# Altium schematic sheet [09] - SWITCHES AND INPUTS.SchDoc
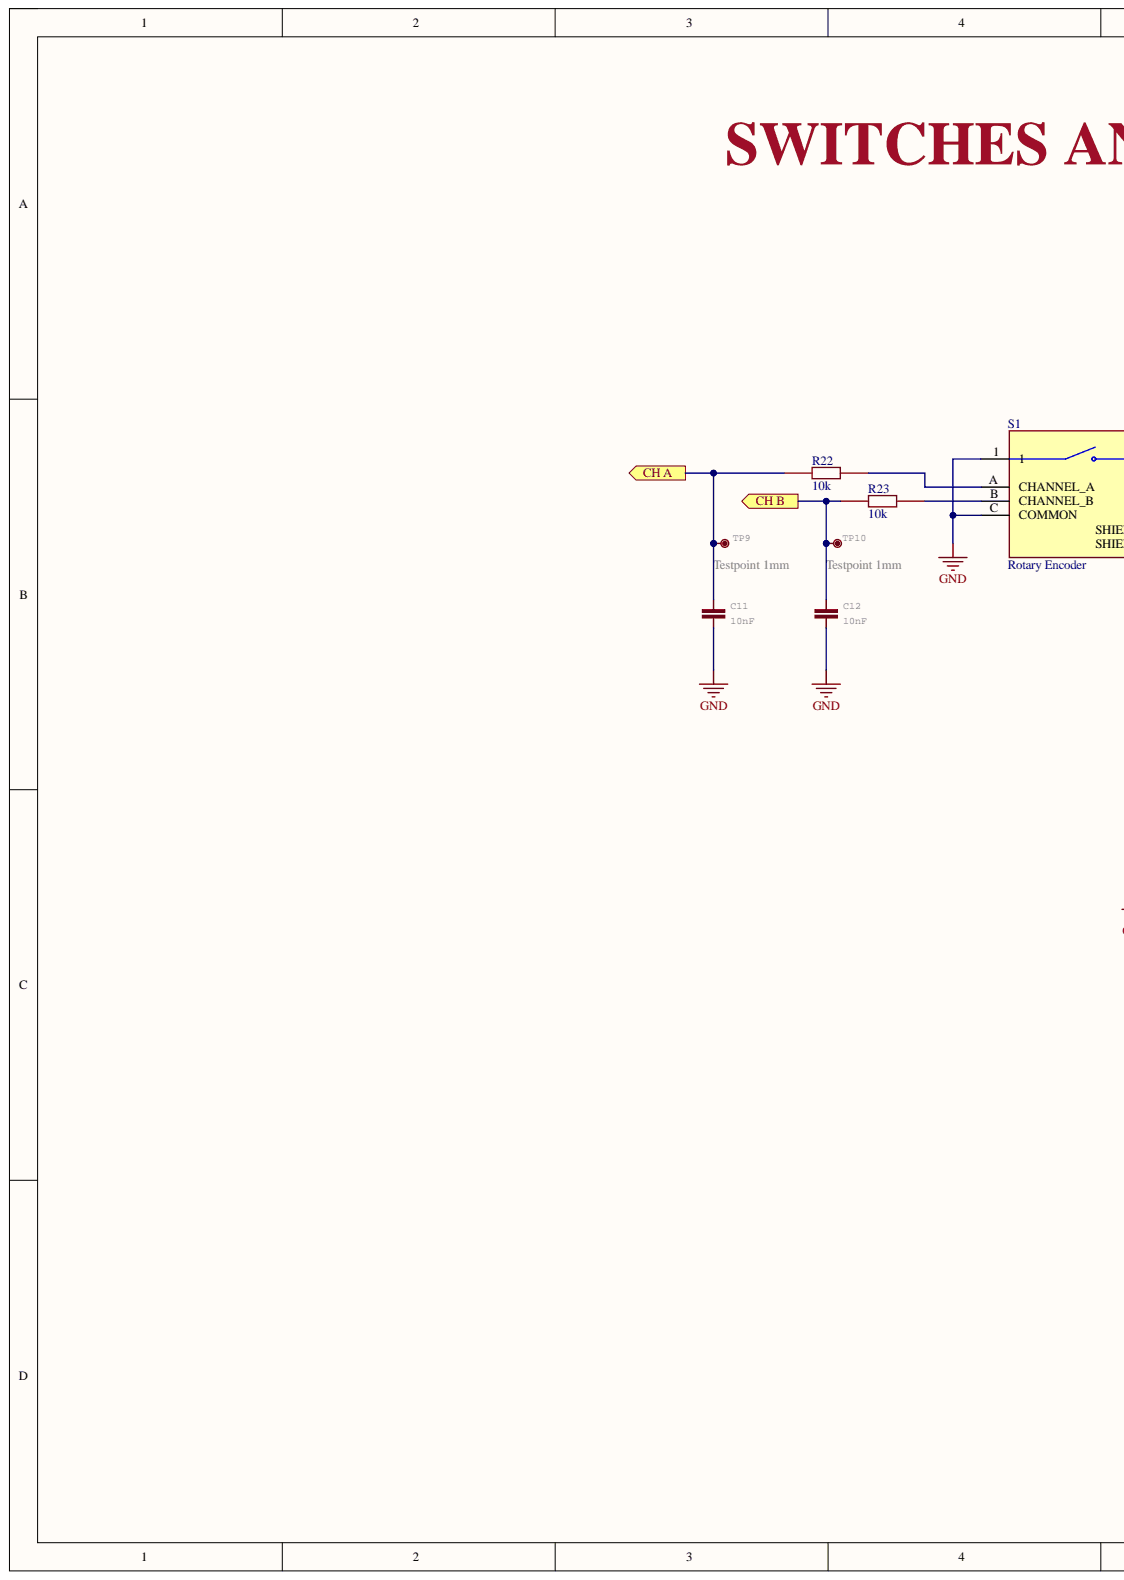
[diagram p0: [09] - SWITCHES AND INPUTS.SchDoc, left]
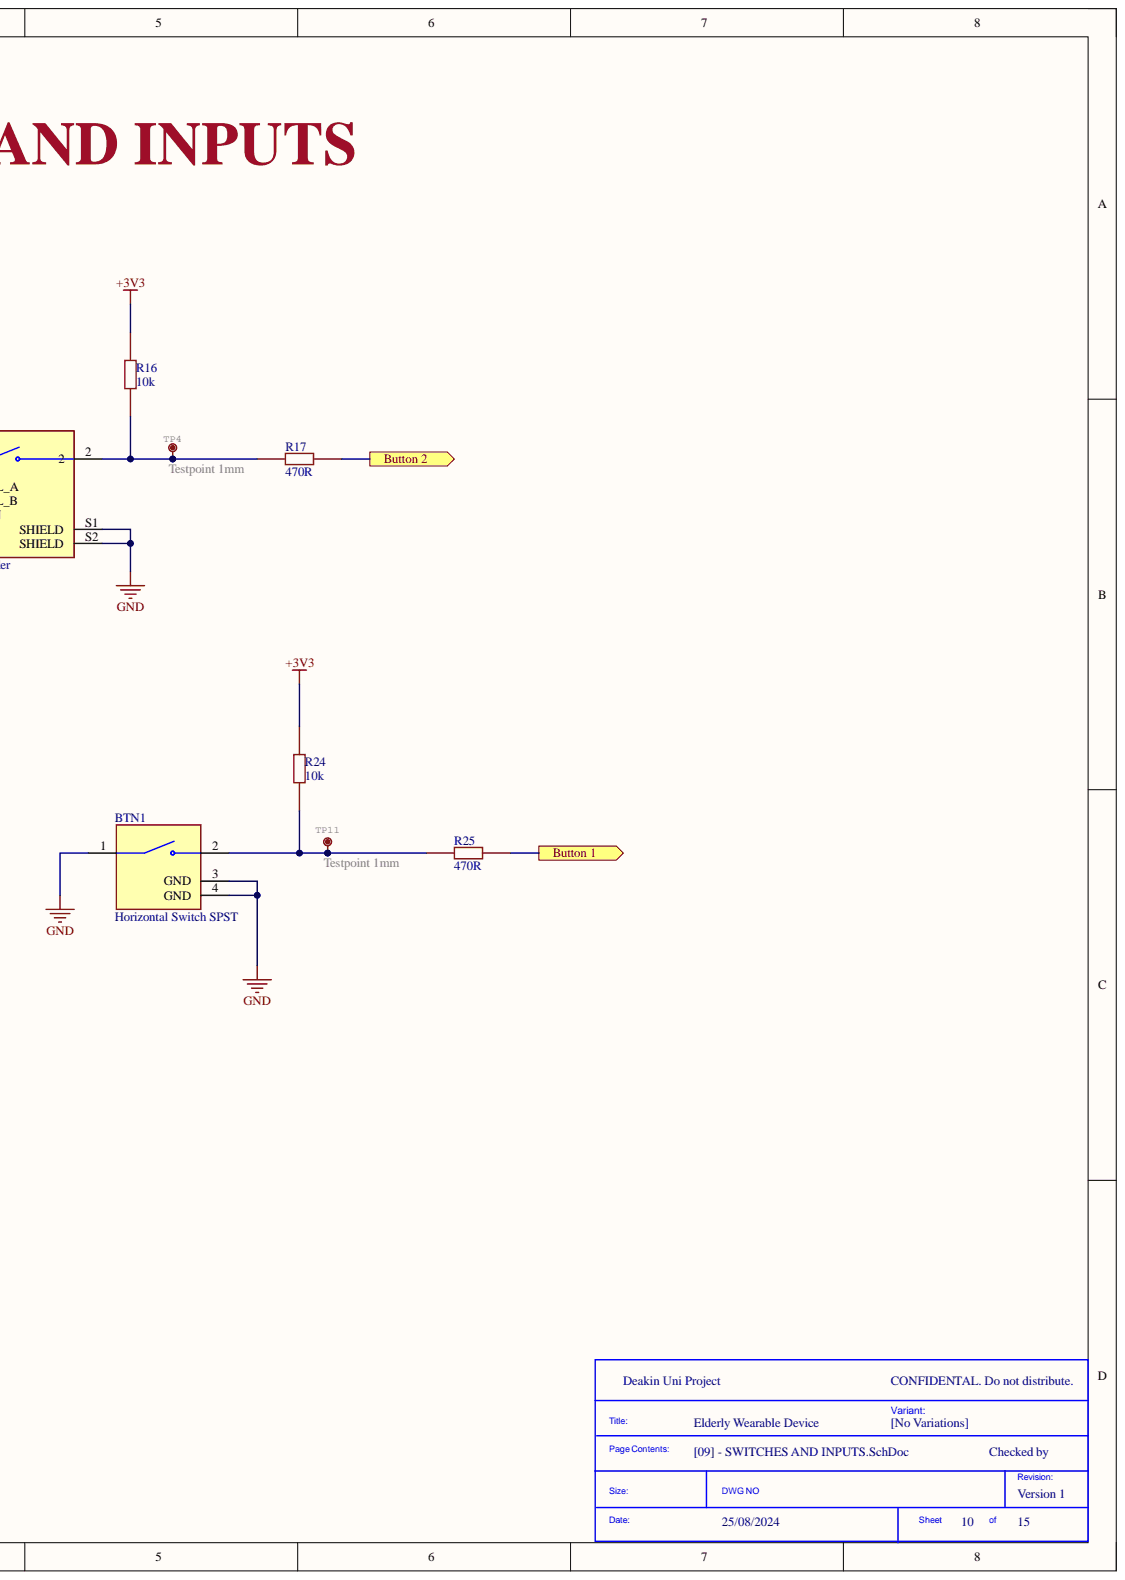
[diagram p1: [09] - SWITCHES AND INPUTS.SchDoc, right]

## Parts
BTN1  Horizontal Switch SPST  [Horizontal_Switch]
C11  10nF  [C_0402]
C12  10nF  [C_0402]
R16  10k  [R_0603]
R17  470R  [R_0603]
R22  10k  [R_0603]
R23  10k  [R_0603]
R24  10k  [R_0603]
R25  470R  [R_0603]
S1  Rotary Encoder  [Rotary_Encoder]
TP4  Testpoint 1mm  [Testpoint_1mm]
TP9  Testpoint 1mm  [Testpoint_1mm]
TP10  Testpoint 1mm  [Testpoint_1mm]
TP11  Testpoint 1mm  [Testpoint_1mm]

## Ports
Button 1, Button 2, CH A, CH B

## Nets
+3V3: R16.2 R24.2
GND: BTN1.1 BTN1.3(GND) BTN1.4(GND) C11.2 C12.2 S1.1 S1.C(COMMON) S1.S1(SHIELD) S1.S2(SHIELD)
Button 1: R25.2 ; port Button 1
Button 2: R17.2 ; port Button 2
CH A: C11.1 R22.2 TP9.X ; port CH A
CH B: C12.1 R23.2 TP10.X ; port CH B
NetBTN1_2: BTN1.2 R24.1 R25.1 TP11.X
NetR16_1: R16.1 R17.1 S1.2 TP4.X
NetR22_1: R22.1 S1.A(CHANNEL_A)
NetR23_1: R23.1 S1.B(CHANNEL_B)

## Library data of the parts
BTN1 = Horizontal Switch SPST: Manufacturer: CUI Devices; Manufacturer Part Number: TS11-674-80-BK-160-RA-D; ALL GOOD: OK_DONE; Component Kind: Standard; Description: Horizontal Switch SPST; Package: NA; Supplier: Digi-Key; Supplier Part Number: 2223-TS11-674-80-BK-160-RA-D-ND; Library Name: Elderly_Wearable_Device.SCHLIB
C11 C12 = C_0402_10nF: SMD Capacitor; MANUFACTURER: Murata Electronics; Manufacturer Part Number: GRM155R71C103KA01D; ALL GOOD: OK_DONE; Component Kind: Standard; Package: 0402; Supplier: Digi-Key; Supplier Part Number: 490-1313-1-ND; Library Name: Elderly_Wearable_Device.SCHLIB
R16 R22-R24 = R_0603_10k: SMD Resistor; Manufacturer: Yageo; Manufacturer Part Number: RC0603FR-0710KL; ALL GOOD: OK_DONE; Component Kind: Standard; Package: 0603; Supplier: Digi-Key; Supplier Part Number: 311-10.0KHRCT-ND; Library Name: Elderly_Wearable_Device.SCHLIB
R17 R25 = R_0603_470R: SMD Resistor; Manufacturer: Yageo; Manufacturer Part Number: RC0603FR-07470RL; ALL GOOD: OK_DONE; Component Kind: Standard; Package: 0603; Supplier: Digi-Key; Supplier Part Number: 311-470HRCT-ND; Library Name: Elderly_Wearable_Device.SCHLIB
S1 = Rotary Encoder: Rotary Encoder Mechanical 24 Quadrature (Incremental) Right Angle; Manufacturer: Bourns Inc.; Manufacturer Part Number: PEC12R-2220F-S0024; ALL GOOD: OK_DONE; Component Kind: Standard; Package: NA; Supplier: Digi-Key; Supplier Part Number: PEC12R-2220F-S0024-ND; Library Name: Elderly_Wearable_Device.SCHLIB
TP4 TP9-TP11 = Testpoint 1mm: MANUFACTURER: NA; ALL GOOD: OK_DONE; Component Kind: Standard; Description: Testpoint 1mm; Manufacturer Part Number: NA; Package: TP-1.00MM; Supplier: NA; Supplier Part Number: NA; Library Name: Elderly_Wearable_Device.SCHLIB
